# Altium schematic sheet RTU.SchDoc(RTU)
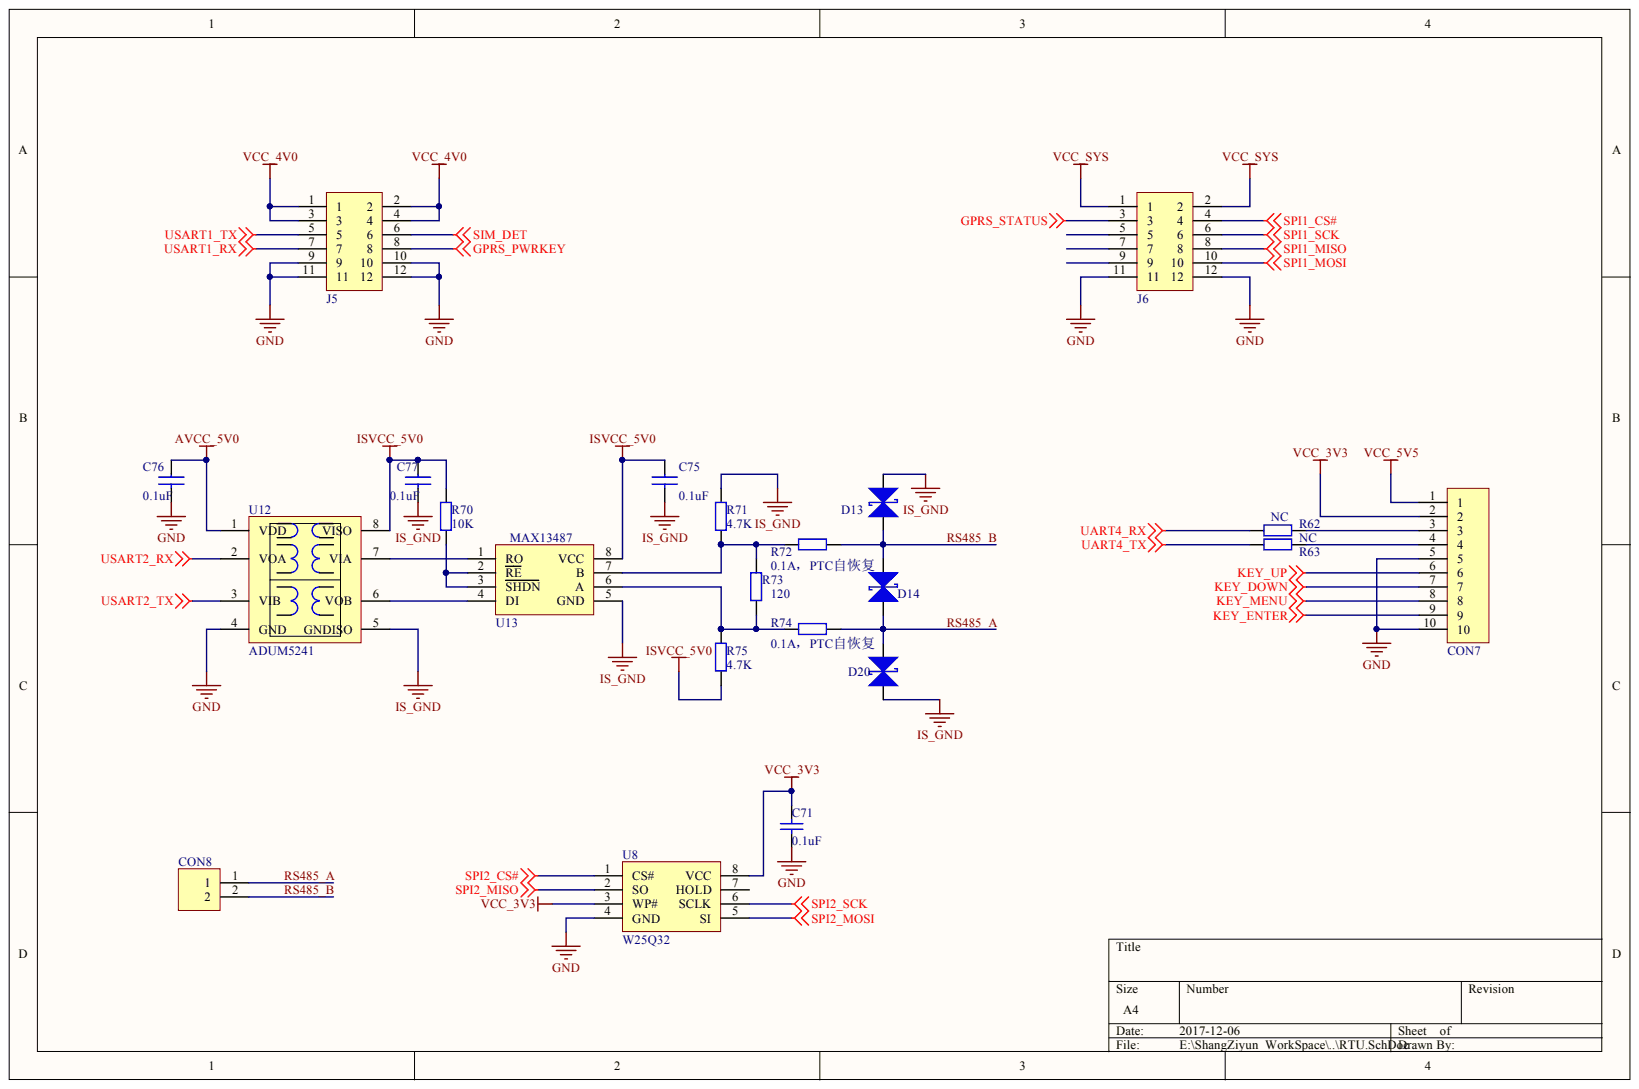
[diagram p0: RTU.SchDoc]

## Parts
C71  value 0.1uF  [0603C]  (hidden comment: Capacitor)
C75  value 0.1uF  [0603C]  (hidden comment: CAP NP)
C76  value 0.1uF  [0603C]  (hidden comment: CAP NP)
C77  value 0.1uF  [0603C]  (hidden comment: CAP NP)
CON7  CONNECTOR_10P  [XH2.54-10P]  (value not drawn)
CON8  CONNECTOR_2PIN  [HT5.08-2W]  (value not drawn)
D13  D_TVS  [DO214AC(SMA)]  (value not drawn)
D14  D_TVS  [DO214AC(SMA)]  (value not drawn)
D20  D_TVS  [DO214AC(SMA)]  (value not drawn)
J5  Header 6x2  [SOP2.0-2x6]  (value not drawn)
J6  Header 6x2  [SOP2.0-2x6]  (value not drawn)
R62  value NC  [L0603]  (hidden comment: Resistor)
R63  value NC  [L0603]  (hidden comment: Resistor)
R70  value 10K  [L0603]  (hidden comment: Resistor)
R71  value 4.7K  [L0603]  (hidden comment: Resistor)
R72  value 0.1A，PTC自恢复  [1812]  (hidden comment: Resistor)
R73  value 120  [0805R]  (hidden comment: Resistor)
R74  value 0.1A，PTC自恢复  [1812]  (hidden comment: Resistor)
R75  value 4.7K  [L0603]  (hidden comment: Resistor)
U8  W25Q32  [SOP8(8x6)]
U12  ADUM5241  [SOP8]
U13  MAX13487  [SOP8]

## Nets
AVCC_5V0: C76.1 U12.1(VDD)
GND: C71.2 C76.2 CON7.5 CON7.10 J5.9 J5.10 J5.11 J5.12 J6.11 J6.12 U8.4(GND) U12.4(GND)
GPRS_PWRKEY: J5.8
GPRS_STATUS: J6.3
IS_GND: C75.2 C77.2 D13.1 D20.2 R71.1 U12.5(GNDISO) U13.5(GND)
ISVCC_5V0: C75.1 C77.1 R70.2 R75.2 U12.8(VISO) U13.8(VCC)
KEY_DOWN: CON7.7
KEY_ENTER: CON7.9
KEY_MENU: CON7.8
KEY_UP: CON7.6
SIM_DET: J5.6
SPI1_CS#: J6.4
SPI1_MISO: J6.8
SPI1_MOSI: J6.10
SPI1_SCK: J6.6
SPI2_CS#: U8.1(CS#)
SPI2_MISO: U8.2(SO)
SPI2_MOSI: U8.5(SI)
SPI2_SCK: U8.6(SCLK)
UART4_RX: R62.2
UART4_TX: R63.2
USART1_RX: J5.7
USART1_TX: J5.5
USART2_RX: U12.2(VOA)
USART2_TX: U12.3(VIB)
VCC_3V3: C71.1 CON7.2 U8.3(WP#) U8.8(VCC)
VCC_4V0: J5.1 J5.2 J5.3 J5.4
VCC_5V5: CON7.1
VCC_SYS: J6.1 J6.2
RS485_A: CON8.1 D14.2 D20.1 R74.1
RS485_B: CON8.2 D13.2 D14.1 R72.1
NetCON7_3: CON7.3 R62.1
NetCON7_4: CON7.4 R63.1
NetR70_1: R70.1 U13.2(RE) U13.3(SHDN)
NetR71_2: R71.2 R72.2 R73.2 U13.7(B)
NetR73_1: R73.1 R74.2 R75.1 U13.6(A)
NetU12_6: U12.6(VOB) U13.4(DI)
NetU12_7: U12.7(VIA) U13.1(RO)

Not connected: J6 5 7 9; U8 7(HOLD)

## Library data of the parts
C71 = Cap: Component Kind: Standard; Description: Capacitor; LatestRevisionDate: 17-Jul-2002; LatestRevisionNote: Re-released for DXP Platform.; Library Name: Miscellaneous Devices.IntLib; PackageDescription: Radial Cap, Thru-Hole; 2 Leads; 0.3 in Pin Spacing; PackageReference: RAD-0.3; Published: 8-Jun-2000; Signal Integrity: Cap; Simulation: CAP; Publisher: Altium Limited
CON7 = CONNECTOR_10P: Value: XH2.54-10P卧式贴片; Component Kind: Standard; Library Name: MyLib.SCHLIB
CON8 = CONNECTOR_2PIN: Value: HT5.08-2W; Component Kind: Standard; Library Name: MyLib.SCHLIB
D13 D14 D20 = D_TVS: Value: SMAJ6.8CA; Component Kind: Standard; Library Name: MyLib.SCHLIB
J5 J6 = Header 6x2: Value: 2.0间距贴片双排针; Component Kind: Standard; Library Name: MyLib.SCHLIB
R62 R63 R70 R71 R73 R75 = Res: Component Kind: Standard; Library Name: Lib1.SCHLIB
R72 R74 = Res: Value: 0.1A，PTC自恢复; Component Kind: Standard; Library Name: Lib1.SCHLIB
U8 = W25Q64: Define: (NC); Component Kind: Standard; Value: W25Q32; Library Name: Bio.SchLib
C75-C77 = CAP NP: Component Kind: Standard; Library Name: MAINBOARD_Library.SchLib
U12 = ADUM5241: Component Kind: Standard; Library Name: MyLib.SCHLIB; Value: ADUM5241
U13 = MAX13487: Component Kind: Standard; Value: MAX13487; Library Name: Bio.SchLib
